# Altium schematic sheet Sheet3.SchDoc
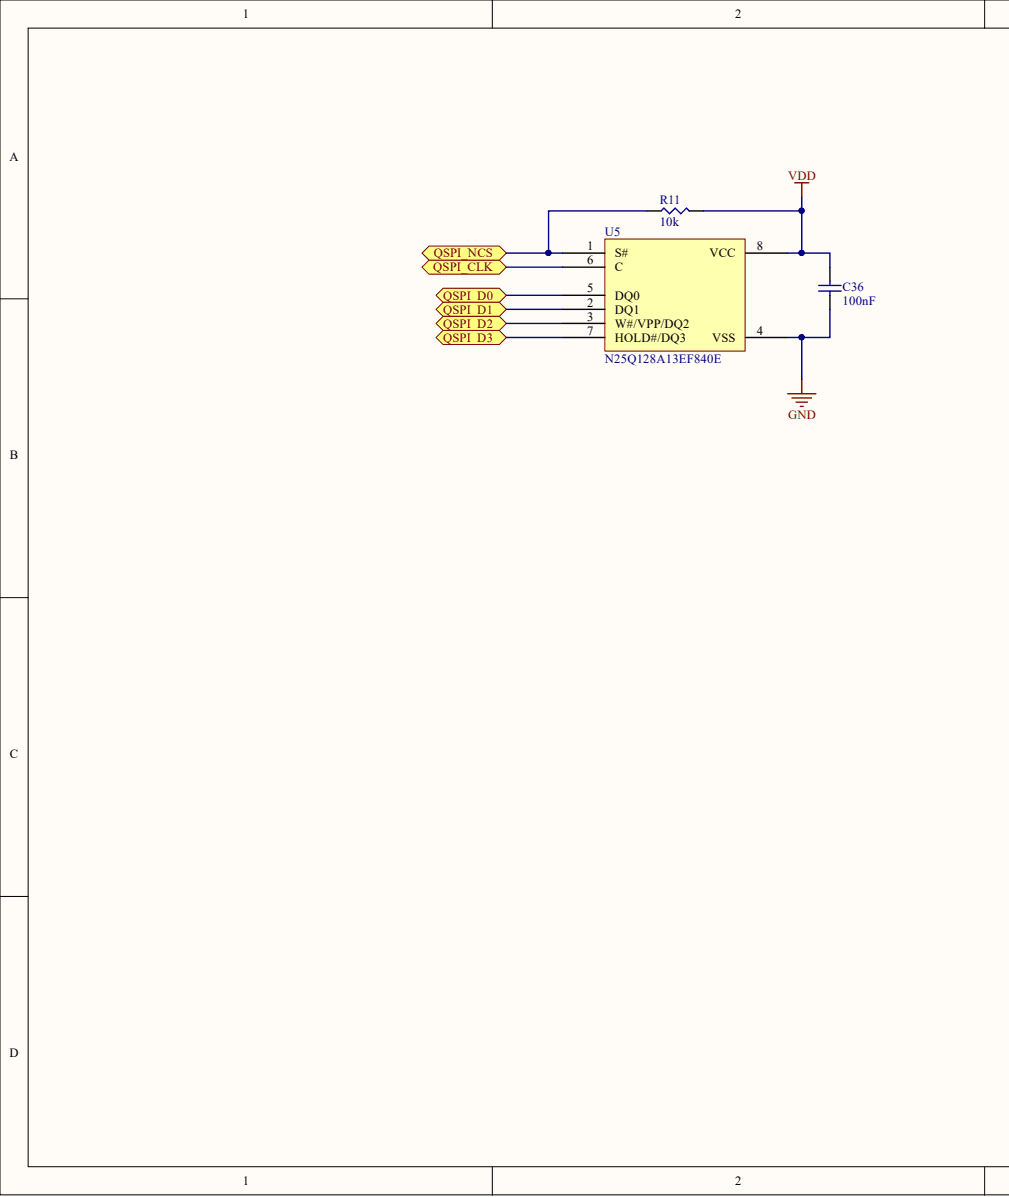
[diagram p0: Sheet3.SchDoc, left]
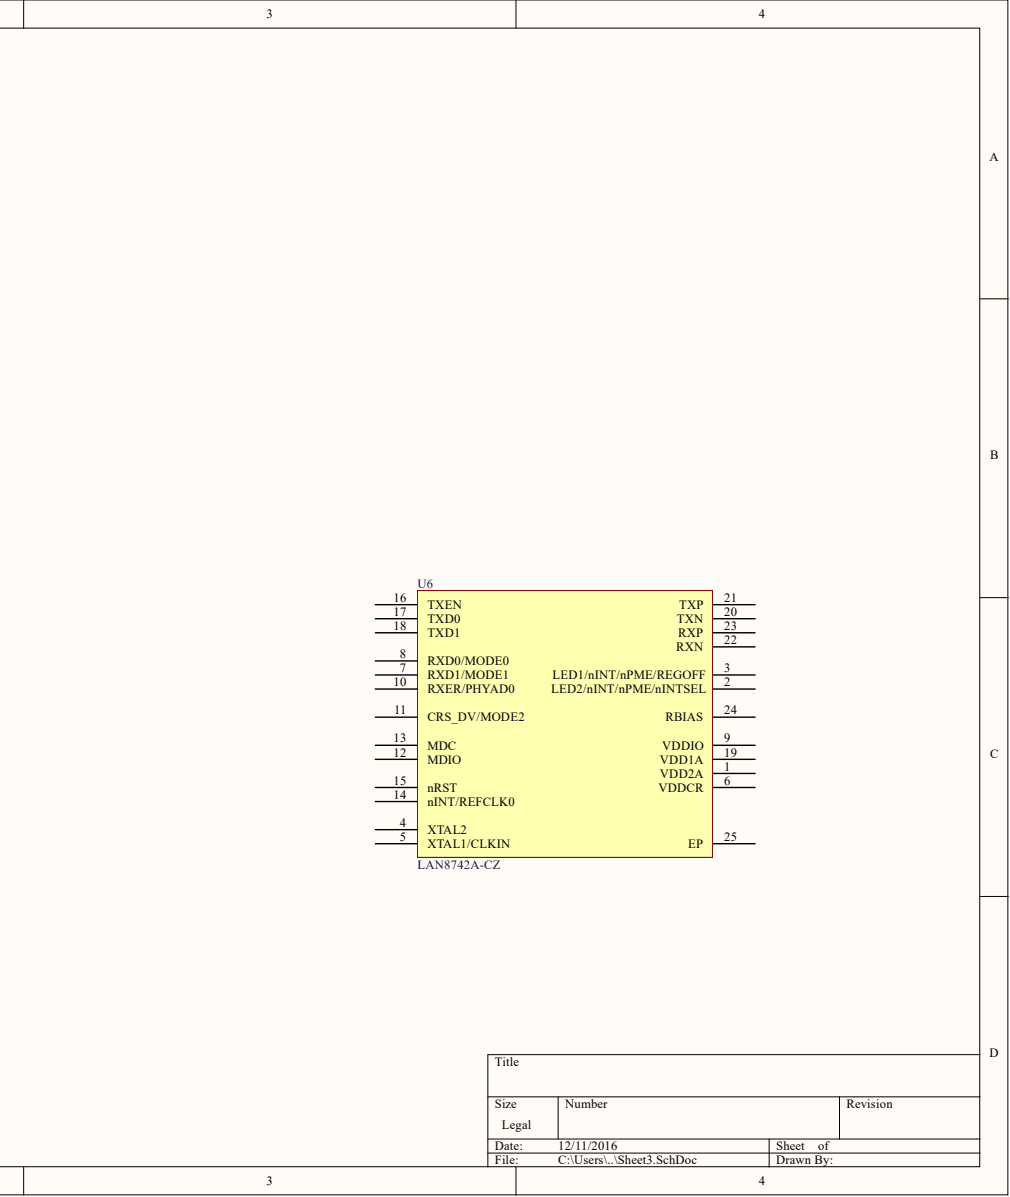
[diagram p1: Sheet3.SchDoc, right]

## Parts
C36  100nF  [C0402]
R11  10k  [R0402]
U5  N25Q128A13EF840E  [SON127P800X100_HS-9N]
U6  LAN8742A-CZ  [QFN50P400X400X100_HS-25N]

## Ports
QSPI_CLK, QSPI_D0, QSPI_D1, QSPI_D2, QSPI_D3, QSPI_NCS

## Nets
GND: C36.1 U5.4(VSS)
VDD: C36.2 R11.2 U5.8(VCC)
QSPI_CLK: U5.6(C) ; port QSPI_CLK
QSPI_D0: U5.5(DQ0) ; port QSPI_D0
QSPI_D1: U5.2(DQ1) ; port QSPI_D1
QSPI_D2: U5.3(W#/VPP/DQ2) ; port QSPI_D2
QSPI_D3: U5.7(HOLD#/DQ3) ; port QSPI_D3
QSPI_NCS: R11.1 U5.1(S#) ; port QSPI_NCS

Not connected: U6 1(VDD2A) 2(LED2/nINT/nPME/nINTSEL) 3(LED1/nINT/nPME/REGOFF) 4(XTAL2) 5(XTAL1/CLKIN) 6(VDDCR) 7(RXD1/MODE1) 8(RXD0/MODE0) 9(VDDIO) 10(RXER/PHYAD0) 11(CRS_DV/MODE2) 12(MDIO) 13(MDC) 14(nINT/REFCLK0) 15(nRST) 16(TXEN) 17(TXD0) 18(TXD1) 19(VDD1A) 20(TXN) 21(TXP) 22(RXN) 23(RXP) 24(RBIAS) 25(EP)

## Library data of the parts
C36 = GRM155R71C104KA88J: CAP CER 0.1UF 16V X7R 0402; Manufacturer: Murata Electronics North America; Capacitance: 0.10µF; Tolerance: ±10%; Applications: General Purpose; Category: Capacitors; Component Kind: Standard; ComponentLink1Description: http://www.murata.com/~/media/webrenewal/support/library/catalog/products/capacitor/mlcc/c02e.pdf; ComponentLink1URL: http://www.murata.com/~/media/webrenewal/support/library/catalog/products/capacitor/mlcc/c02e.pdf; Features: -; Height - Seated (Max): -; Lead Spacing: -; Library Name: Main.SchLib; Manufacturer Part Number: GRM155R71C104KA88J; Mounting Type: Surface Mount, MLCC; Operating Temperature: -55°C ~ 125°C; Package / Case: 0402 (1005 Metric); Packaging: Cut Tape (CT); Part Status: Active; Pricing 1: 1=0.1, 10=0.012, 50=0.0066, 100=0.0055, 250=0.00452, 500=0.00386, 1000=0.003, 5000=0.0025, 10000=0.0023 (USD); Ratings: -; RoHS: RoHS Compliant; Size / Dimension: 0.039" L x 0.020" W (1.00mm x 0.50mm); Stock 1: 28696221; Supplier 1: Digi-Key; Supplier Part Number 1: 490-6328-1-ND; Temperature Coefficient: X7R; Thickness (Max): 0.022" (0.55mm); Voltage - Rated: 16V
R11 = RC0402FR-0710KL: RES SMD 10K OHM 1% 1/16W 0402; Manufacturer: Yageo; Power (Watts): 0.063W, 1/16W; Temperature Coefficient: ±100ppm/°C; Category: Resistors; Component Kind: Standard; ComponentLink1Description: http://www.yageo.com/NewPortal/yageodocoutput?fileName=/pdf/R-Chip/PYu-RC_Group_51_RoHS_L_4.pdf; ComponentLink1URL: http://www.yageo.com/NewPortal/yageodocoutput?fileName=/pdf/R-Chip/PYu-RC_Group_51_RoHS_L_4.pdf; Composition: Thick Film; Failure Rate: -; Features: Moisture Resistant; Height - Seated (Max): 0.016" (0.40mm); Library Name: Main.SchLib; Manufacturer Part Number: RC0402FR-0710KL; Number of Terminations: 2; Operating Temperature: -55°C ~ 155°C; Package / Case: 0402 (1005 Metric); Packaging: Cut Tape (CT); Part Status: Active; Pricing 1: 1=0.1, 10=0.013, 25=0.0096, 100=0.0054, 250=0.00412, 500=0.00328, 1000=0.00242, 2500=0.0021, 5000=0.00173 (USD); Resistance (Ohms): 10k; RoHS: RoHS Compliant; Size / Dimension: 0.039" L x 0.020" W (1.00mm x 0.50mm); Stock 1: 13115833; Supplier 1: Digi-Key; Supplier Device Package: 0402; Supplier Part Number 1: 311-10.0KLRCT-ND; Tolerance: ±1%
U5 = N25Q128A13EF840E: IC FLASH 128MBIT 108MHZ 8VDFPN; Manufacturer: Micron Technology Inc.; Interface: SPI Serial; Memory Size: 128M (32Mx4); Memory Type: FLASH - NOR; Voltage - Supply: 2.7 V ~ 3.6 V; Category: Integrated Circuits (ICs); Component Kind: Standard; ComponentLink1Description: http://www.micron.com/~/media/Documents/Products/Data%20Sheet/NOR%20Flash/Serial%20NOR/N25Q/n25q_128mb_3v_65nm.pdf; ComponentLink1URL: http://www.micron.com/~/media/Documents/Products/Data%20Sheet/NOR%20Flash/Serial%20NOR/N25Q/n25q_128mb_3v_65nm.pdf; Format - Memory: FLASH; Library Name: Main.SchLib; Manufacturer Part Number: N25Q128A13EF840E; Operating Temperature: -40°C ~ 85°C (TA); Package / Case: 8-VDFN Exposed Pad; Packaging: Tray; Part Status: Active; Pricing 1: 1=1.58, 10=1.441, 25=1.4024, 50=1.395, 100=1.2469, 250=1.2432, 500=1.2247, 1000=1.17216, 5000=1.0915 (USD); RoHS: RoHS Compliant; Speed: 108MHz; Stock 1: 9877; Supplier 1: Digi-Key; Supplier Device Package: 8-VDFPN (MLP8) (8x6); Supplier Part Number 1: 557-1561-ND
U6 = LAN8742A-CZ: IC TXRX ETHERNET 100MBPS 24QFN; Manufacturer: Microchip Technology; Number of Drivers/Receivers: 1/1; Voltage - Supply: 1.6 V ~ 3.6 V; Category: Integrated Circuits (ICs); Component Kind: Standard; ComponentLink1Description: http://www.microchip.com/mymicrochip/filehandler.aspx?ddocname=en566967; ComponentLink1URL: http://www.microchip.com/mymicrochip/filehandler.aspx?ddocname=en566967; Data Rate: 100Mbps; Duplex: -; Library Name: Main.SchLib; Manufacturer Part Number: LAN8742A-CZ; Mounting Type: Surface Mount; Operating Temperature: 0°C ~ 85°C; Package / Case: 24-VFQFN Exposed Pad; Packaging: Tray; Part Status: Active; Pricing 1: 1=1.24, 25=1.03, 100=0.9373 (USD); Protocol: Ethernet; Receiver Hysteresis: -; RoHS: RoHS Compliant; Stock 1: 1766; Supplier 1: Digi-Key; Supplier Device Package: 24-SQFN (4x4); Supplier Part Number 1: LAN8742A-CZ-ND; Type: Transceiver
